# Altium schematic sheet CONTROL.SCHDOC
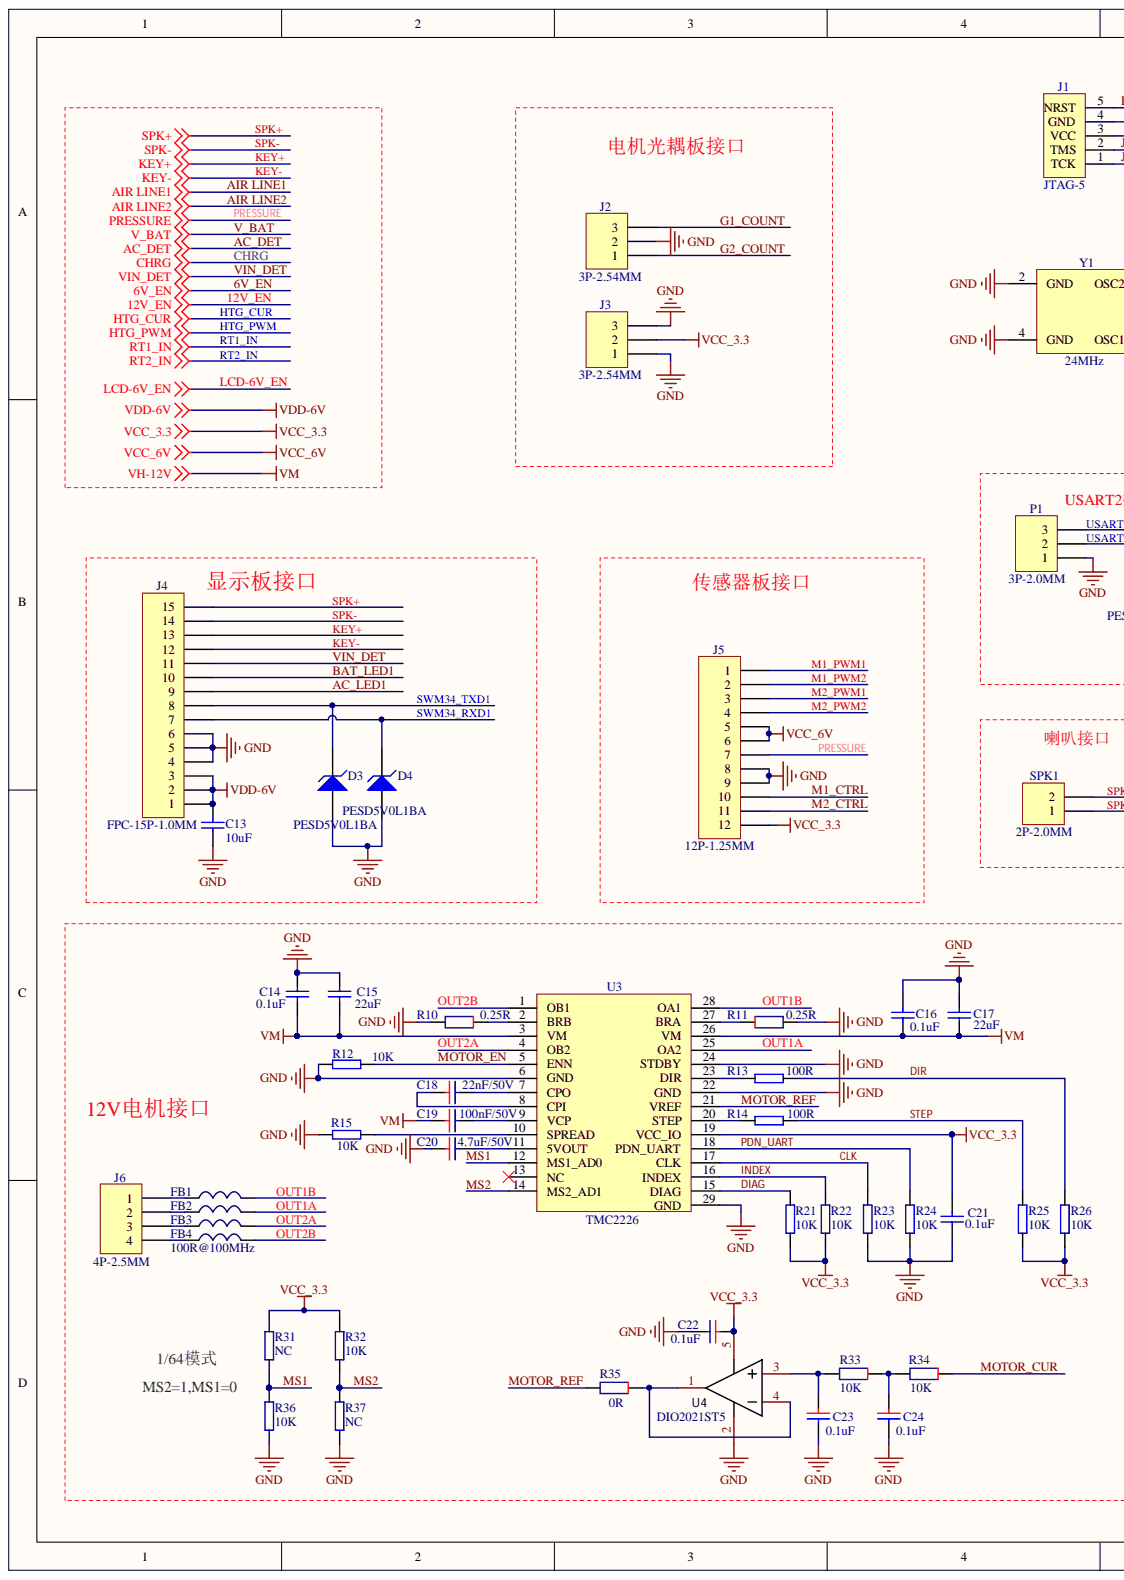
[diagram p0: CONTROL.SCHDOC, left]
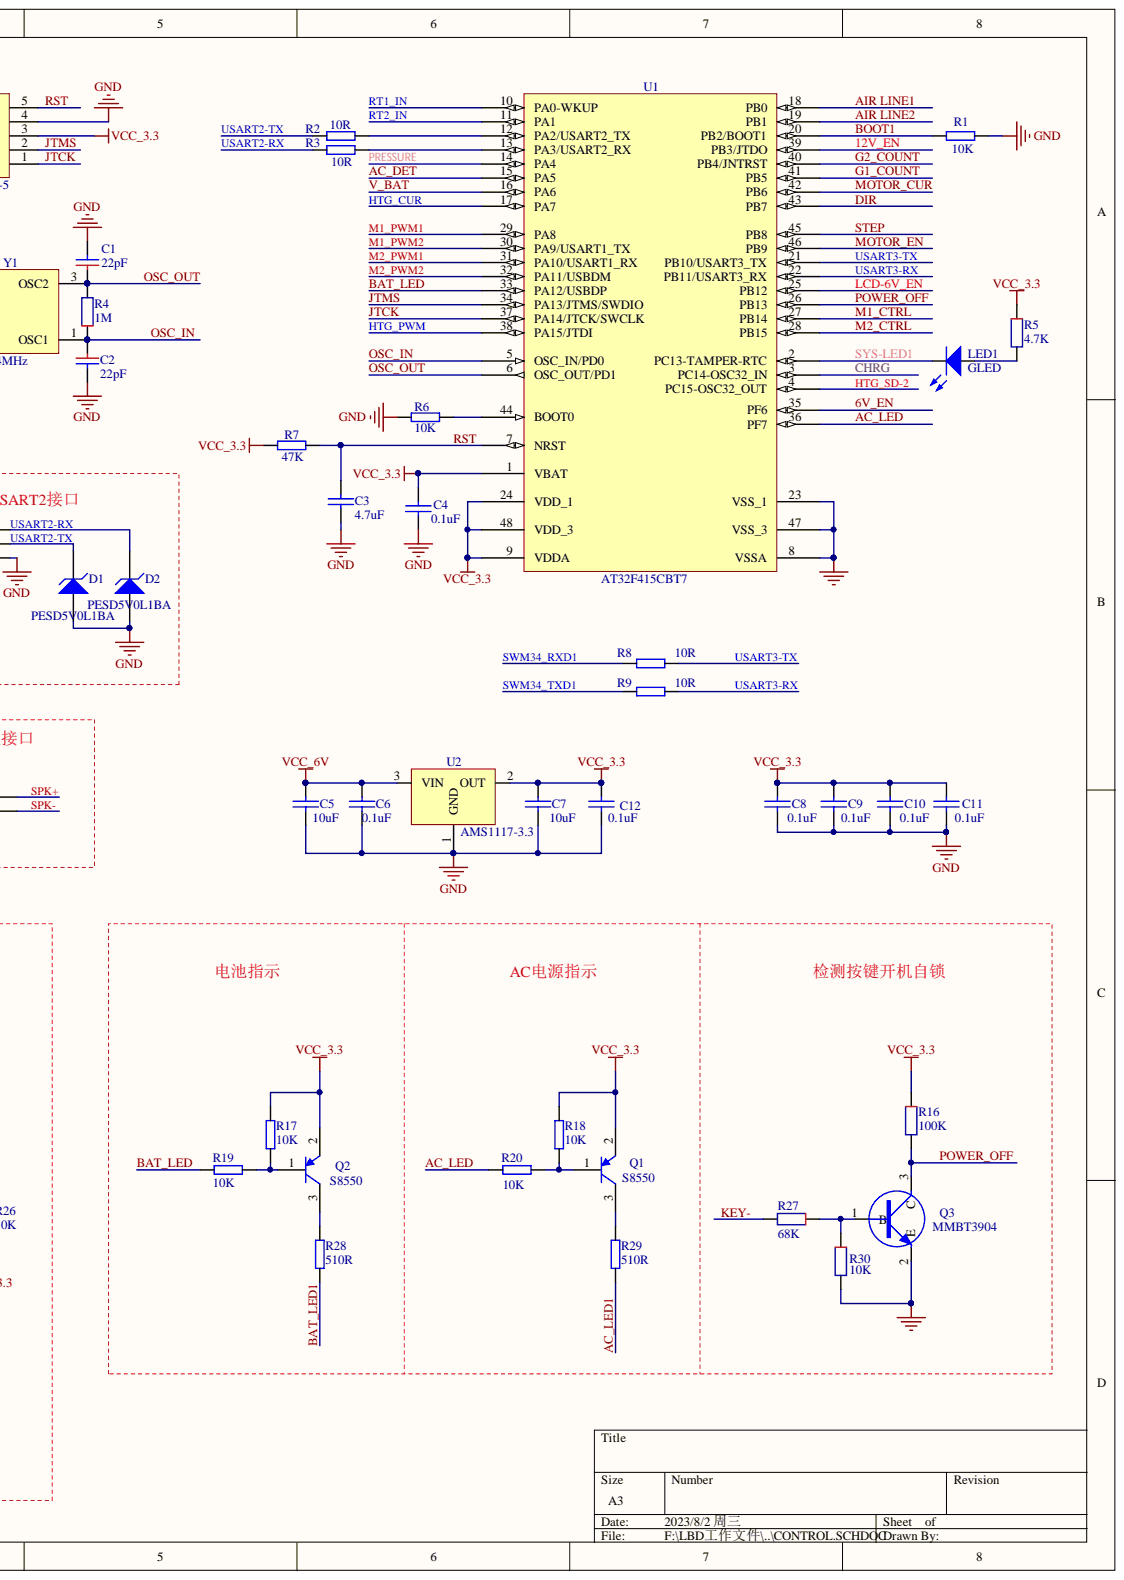
[diagram p1: CONTROL.SCHDOC, right]

## Parts
C1  22pF  [C0402]
C2  22pF  [C0402]
C3  4.7uF  [C0402]
C4  0.1uF  [C0402]
C5  10uF  [C0805]
C6  0.1uF  [C0402]
C7  10uF  [C0805]
C8  0.1uF  [C0402]
C9  0.1uF  [C0402]
C10  0.1uF  [C0402]
C11  0.1uF  [C0402]
C12  0.1uF  [C0402]
C13  10uF  [C1206]
C14  0.1uF  [C0402]
C15  22uF  [C1206]
C16  0.1uF  [C0402]
C17  22uF  [C1206]
C18  22nF/50V  [C0603]
C19  100nF/50V  [C0603]
C20  4.7uF/50V  [C0603]
C21  0.1uF  [c0402]
C22  0.1uF  [C0402]
C23  0.1uF  [C0402]
C24  0.1uF  [C0402]
D1  PESD5V0L1BA  [SOD-323]
D2  PESD5V0L1BA  [SOD-323]
D3  PESD5V0L1BA  [SOD-323]
D4  PESD5V0L1BA  [SOD-323]
FB1  100R@100MHz  [L0805]
FB2  100R@100MHz  [L0805]
FB3  100R@100MHz  [L0805]
FB4  100R@100MHz  [L0805]
J1  JTAG-5  [CON5/1.27MM]
J2  3P-2.54MM  [HDR1X3]
J3  3P-2.54MM  [HDR1X3]
J4  FPC-15P-1.0MM  [FPC-1.0-15P]
J5  12P-1.25MM  [CON12P-1.25MM]
J6  4P-2.5MM  [CON4/2.5/SMD]
LED1  GLED  [LED0603-G]
P1  3P-2.0MM  [PH2.0-3P]
Q1  S8550  [SOT_23]
Q2  S8550  [SOT_23]
Q3  MMBT3904  [SOT_23]
R1  10K  [R0402]
R2  10R  [R0402]
R3  10R  [R0402]
R4  1M  [R0402]
R5  4.7K  [R0402]
R6  10K  [R0402]
R7  47K  [R0402]
R8  10R  [R0402]
R9  10R  [R0402]
R10  0.25R  [R1206]
R11  0.25R  [R1206]
R12  10K  [R0402]
R13  100R  [R0402]
R14  100R  [R0402]
R15  10K  [R0402]
R16  100K  [R0402]
R17  10K  [R0402]
R18  10K  [R0402]
R19  10K  [R0402]
R20  10K  [R0402]
R21  10K  [R0402]
R22  10K  [R0402]
R23  10K  [R0402]
R24  10K  [R0402]
R25  10K  [R0402]
R26  10K  [R0402]
R27  68K  [R0402]
R28  510R  [R0805]
R29  510R  [R0805]
R30  10K  [R0402]
R31  NC  [R0402]
R32  10K  [R0402]
R33  10K  [R0402]
R34  10K  [R0402]
R35  0R  [R0402]
R36  10K  [R0402]
R37  NC  [R0402]
SPK1  2P-2.0MM  [PH2.0-2P弯插]
U1  AT32F415CBT7  [LQFP 48 7X7MM]
U2  AMS1117-3.3  [SOT-223]
U3  TMC2226  [HTSSOP28]
U4  DIO2021ST5  [SOT-23-5_L3.0-W1.5-P0.80-LS3.0-BL]
Y1  24MHz  [TSX3225]

## Nets
GND: C1.2 C2.2 C3.2 C4.2 C5.1 C6.1 C7.1 C8.2 C9.2 C10.2 C11.2 C12.1 C13.1 C14.1 C15.1 C16.2 C17.2 C20.1 C21.2 C22.2 C23.2 C24.2 D1.1 D2.1 D3.1 D4.1 J1.4(GND) J2.2 J3.1 J3.3 J4.4 J4.5 J4.6 J5.8 J5.9 P1.1 Q3.2 R1.1 R6.1 R10.2 R11.2 R12.1 R15.2 R23.1 R24.1 R30.2 R36.1 R37.1 U1.8(VSSA) U1.23(VSS_1) U1.47(VSS_3) U2.1 U3.6(GND) U3.22(GND) U3.24(STDBY) U3.29(GND) U4.2 Y1.2(GND) Y1.4(GND)
VCC_3.3: C4.1 C7.2 C8.1 C9.1 C10.1 C11.1 C12.2 C21.1 C22.1 J1.3(VCC) J3.2 J5.12 Q1.2 Q2.2 R5.1 R7.2 R16.1 R17.2 R18.1 R21.1 R22.1 R25.1 R26.1 R31.2 R32.2 U1.1(VBAT) U1.9(VDDA) U1.24(VDD_1) U1.48(VDD_3) U2.2 U3.19(VCC_IO) U4.5
VCC_6V: C5.2 C6.2 J5.5 J5.6 U2.3
VDD-6V: C13.2 J4.1 J4.2 J4.3
VH-12V: C14.2 C15.2 C16.1 C17.1 C19.1 U3.3(VM) U3.26(VM)
6V_EN: U1.35(PF6)
12V_EN: U1.39(PB3/JTDO)
AC_DET: U1.15(PA5)
AC_LED: R20.2 U1.36(PF7)
AC_LED1: J4.9 R29.1
AIR LINE1: U1.18(PB0)
AIR LINE2: U1.19(PB1)
BAT_LED: R19.1 U1.33(PA12/USBDP)
BAT_LED1: J4.10 R28.1
BOOT1: R1.2 U1.20(PB2/BOOT1)
CHRG: U1.3(PC14-OSC32_IN)
CLK: R23.2 U3.17(CLK)
DIAG: R21.2 U3.15(DIAG)
DIR: R13.2 R26.2 U1.43(PB7)
G1_COUNT: J2.3 U1.41(PB5)
G2_COUNT: J2.1 U1.40(PB4/JNTRST)
HTG_CUR: U1.17(PA7)
HTG_PWM: U1.38(PA15/JTDI)
HTG_SD-2: U1.4(PC15-OSC32_OUT)
INDEX: R22.2 U3.16(INDEX)
JTCK: J1.1(TCK) U1.37(PA14/JTCK/SWCLK)
JTMS: J1.2(TMS) U1.34(PA13/JTMS/SWDIO)
KEY+: J4.13
KEY-: J4.12 R27.2
LCD-6V_EN: U1.25(PB12)
M1_CTRL: J5.10 U1.27(PB14)
M1_PWM1: J5.1 U1.29(PA8)
M1_PWM2: J5.2 U1.30(PA9/USART1_TX)
M2_CTRL: J5.11 U1.28(PB15)
M2_PWM1: J5.3 U1.31(PA10/USART1_RX)
M2_PWM2: J5.4 U1.32(PA11/USBDM)
MOTOR_CUR: R34.1 U1.42(PB6)
MOTOR_EN: R12.2 U1.46(PB9) U3.5(ENN)
MOTOR_REF: R35.2 U3.21(VREF)
MS1: R31.1 R36.2 U3.12(MS1_AD0)
MS2: R32.1 R37.2 U3.14(MS2_AD1)
OSC_IN: C2.1 R4.1 U1.5(OSC_IN/PD0) Y1.1(OSC1)
OSC_OUT: C1.1 R4.2 U1.6(OSC_OUT/PD1) Y1.3(OSC2)
OUT1A: FB2.2 U3.25(OA2)
OUT1B: FB1.2 U3.28(OA1)
OUT2A: FB3.2 U3.4(OB2)
OUT2B: FB4.2 U3.1(OB1)
PDN_UART: R24.2 U3.18(PDN_UART)
POWER_OFF: Q3.3 R16.2 U1.26(PB13)
PRESSURE: J5.7 U1.14(PA4)
RST: C3.1 J1.5(NRST) R7.1 U1.7(NRST)
RT1_IN: U1.10(PA0-WKUP)
RT2_IN: U1.11(PA1)
SPK+: J4.15 SPK1.2
SPK-: J4.14 SPK1.1
STEP: R14.2 R25.2 U1.45(PB8)
SWM34_RXD1: D4.2 J4.7 R8.1
SWM34_TXD1: D3.2 J4.8 R9.1
SYS-LED1: LED1.2 U1.2(PC13-TAMPER-RTC)
USART2-RX: D2.2 P1.3 R3.1
USART2-TX: D1.2 P1.2 R2.1
USART3-RX: R9.2 U1.22(PB11/USART3_RX)
USART3-TX: R8.2 U1.21(PB10/USART3_TX)
V_BAT: U1.16(PA6)
VIN_DET: J4.11
NetC18_1: C18.1 U3.8(CPI)
NetC18_2: C18.2 U3.7(CPO)
NetC19_2: C19.2 U3.9(VCP)
NetC20_2: C20.2 U3.11(5VOUT)
NetC23_1: C23.1 R33.2 U4.3
NetC24_1: C24.1 R33.1 R34.2
NetFB1_1: FB1.1 J6.1
NetFB2_1: FB2.1 J6.2
NetFB3_1: FB3.1 J6.3
NetFB4_1: FB4.1 J6.4
NetLED1_1: LED1.1 R5.2
NetQ1_1: Q1.1 R18.2 R20.1
NetQ1_3: Q1.3 R29.2
NetQ2_1: Q2.1 R17.1 R19.2
NetQ2_3: Q2.3 R28.2
NetQ3_1: Q3.1 R27.1 R30.1
NetR2_2: R2.2 U1.12(PA2/USART2_TX)
NetR3_2: R3.2 U1.13(PA3/USART2_RX)
NetR6_2: R6.2 U1.44(BOOT0)
NetR10_1: R10.1 U3.2(BRB)
NetR11_1: R11.1 U3.27(BRA)
NetR13_1: R13.1 U3.23(DIR)
NetR14_1: R14.1 U3.20(STEP)
NetR15_1: R15.1 U3.10(SPREAD)
NetR35_1: R35.1 U4.1 U4.4

Not connected: U3 13(NC)

## Library data of the parts
C1 C2 C18-C20 C22-C24 = Cap1: 无极性电容; Value: 100pF; Component Kind: Standard; LatestRevisionDate: 17-Jul-2002; LatestRevisionNote: Re-released for DXP Platform.; PackageDescription: Radial Cap, Thru-Hole; 2 Leads; 0.3 in Pin Spacing; PackageReference: RAD-0.3; Published: 8-Jun-2000; Simulation: CAP; Library Name: Liuqi_Part.SchLib; Publisher: Altium Limited
C3 C4 C8-C11 = CAP: Component Kind: Standard; Library Name: Integrated_Library.IntLib
C5 C7 = CAP: Part Field 1: 25V 0.01uF CAP SMD0603  10%; Component Kind: Standard
C6 C12 = CAP: Part Field 1: 25V 0.1uF CAP  SMD0603  10%; Component Kind: Standard
C13-C17 C21 = Cap: Capacitor; Value: 100pF; Component Kind: Standard; LatestRevisionDate: 17-Jul-2002; LatestRevisionNote: Re-released for DXP Platform.; Library Name: Miscellaneous Devices.IntLib; PackageReference: RAD-0.3; Published: 8-Jun-2000; Simulation: CAP; Publisher: Altium Limited
D1-D4 = D Zener: TVS; Component Kind: Standard; LatestRevisionDate: 17-Jul-2002; LatestRevisionNote: Re-released for DXP Platform.; PackageDescription: Diode, Thru-Hole; 2 Leads; 0.7 in Pin Spacing; PackageReference: DIODE-0.7; Published: 8-Jun-2000; Library Name: Liuqi_Part.SchLib; Publisher: Altium Limited
FB1-FB4 = DIANGAN: Component Kind: Standard; Library Name: MY.SchLib
J2 J3 P1 = Header 3: Header, 3-Pin; Component Kind: Standard; LatestRevisionDate: 17-Jul-2002; LatestRevisionNote: Re-released for DXP Platform.; Library Name: Miscellaneous Connectors.IntLib; Publisher: Altium Limited
J4 = Header 15: Header, 15-Pin; Component Kind: Standard; LatestRevisionDate: 17-Jul-2002; LatestRevisionNote: Re-released for DXP Platform.; Library Name: Miscellaneous Connectors.IntLib; Publisher: Altium Limited
J5 = Header 12: Header, 12-Pin; Component Kind: Standard; LatestRevisionDate: 17-Jul-2002; LatestRevisionNote: Re-released for DXP Platform.; Library Name: Miscellaneous Connectors.IntLib; Publisher: Altium Limited
J6 = Header 4: Header, 4-Pin; Component Kind: Standard; LatestRevisionDate: 17-Jul-2002; LatestRevisionNote: Re-released for DXP Platform.; Library Name: Miscellaneous Connectors.IntLib; Publisher: Altium Limited
LED1 = LED: Component Kind: Standard; Library Name: Integrated_Library.IntLib
Q1 Q2 = PNP: PNP Bipolar Transistor; Component Kind: Standard; LatestRevisionDate: 29-May-2009; LatestRevisionNote: IPC-7351 Footprint Added.; Library Name: Miscellaneous Devices.IntLib; PackageDescription: 3-Pin SOT-23 Package 0.95 mm Pitch, 2.4 mm Lead Span; PackageReference: SOT-23B; PackageVersion: Aug-1999; Published: 8-Jun-2000; Simulation: PNP; Publisher: Altium Limited
Q3 = NPN: Component Kind: Standard
R1-R3 R6-R9 R12-R15 R17-R26 R28 R29 R32 R36 R37 = RES1: Component Kind: Standard; Library Name: Integrated_Library.IntLib
  R32: value: 10K
R4 R5 R10 R11 R16 R27 R30 R33-R35 = Res2: Resistor; Component Kind: Standard; LatestRevisionDate: 17-Jul-2002; LatestRevisionNote: Re-released for DXP Platform.; PackageDescription: Axial Device, Thru-Hole; 2 Leads; 0.4 in Pin Spacing; PackageReference: AXIAL-0.4; Published: 8-Jun-2000; Simulation: RESISTOR; Library Name: Liuqi_Part.SchLib; Publisher: Altium Limited
R31 = RES1: value: 10K; Component Kind: Standard; Library Name: Integrated_Library.IntLib
SPK1 = CON2: Connector, 2-Pin; Component Kind: Standard; LatestRevisionDate: 17-Jul-2002; LatestRevisionNote: Re-released for DXP Platform.; Library Name: Liuqi_Con.SchLib; Publisher: Altium Limited
U1 = AT32F421CBT7: Component Kind: Standard; Library Name: MY.SchLib
U2 = L78L33: Component Kind: Standard; Library Name: 注射泵主板.SCHLIB
U4 = DIO2021ST5: Add into BOM: yes; Amplifier Type: -; Component Kind: Standard; Convert to PCB: yes; Datasheet: https://atta.szlcsc.com/upload/public/pdf/source/20191127/C444372_C4617B8E968AB84A8C79514108B88172.pdf; Device: DIO2021ST5; Iq per channel (Typ): -; JLCPCB Part Class: Extended Part; LCSC Part Name: DIO2021ST5; Manufacturer Part: DIO2021ST5; Name: DIO2021ST5; Number of Circuits: -; Operating Temperature: -; Output Type: -; Supplier: LCSC; Supplier Footprint: SOT-23-5; Supplier Part: C444372; Symbol: DIO2021ST5; Library Name: np100-pcb-a04_1.SCHLIB
Y1 = XTAL_4P: Component Kind: Standard; Library Name: Integrated_Library.IntLib
J1 = JTAG-5: Component Kind: Standard; Library Name: Integrated_Library.IntLib
U3 = TMC2226: Component Kind: Standard; Library Name: MY.SchLib
